# Altium schematic sheet Voltage_sensor_UVW.SchDoc
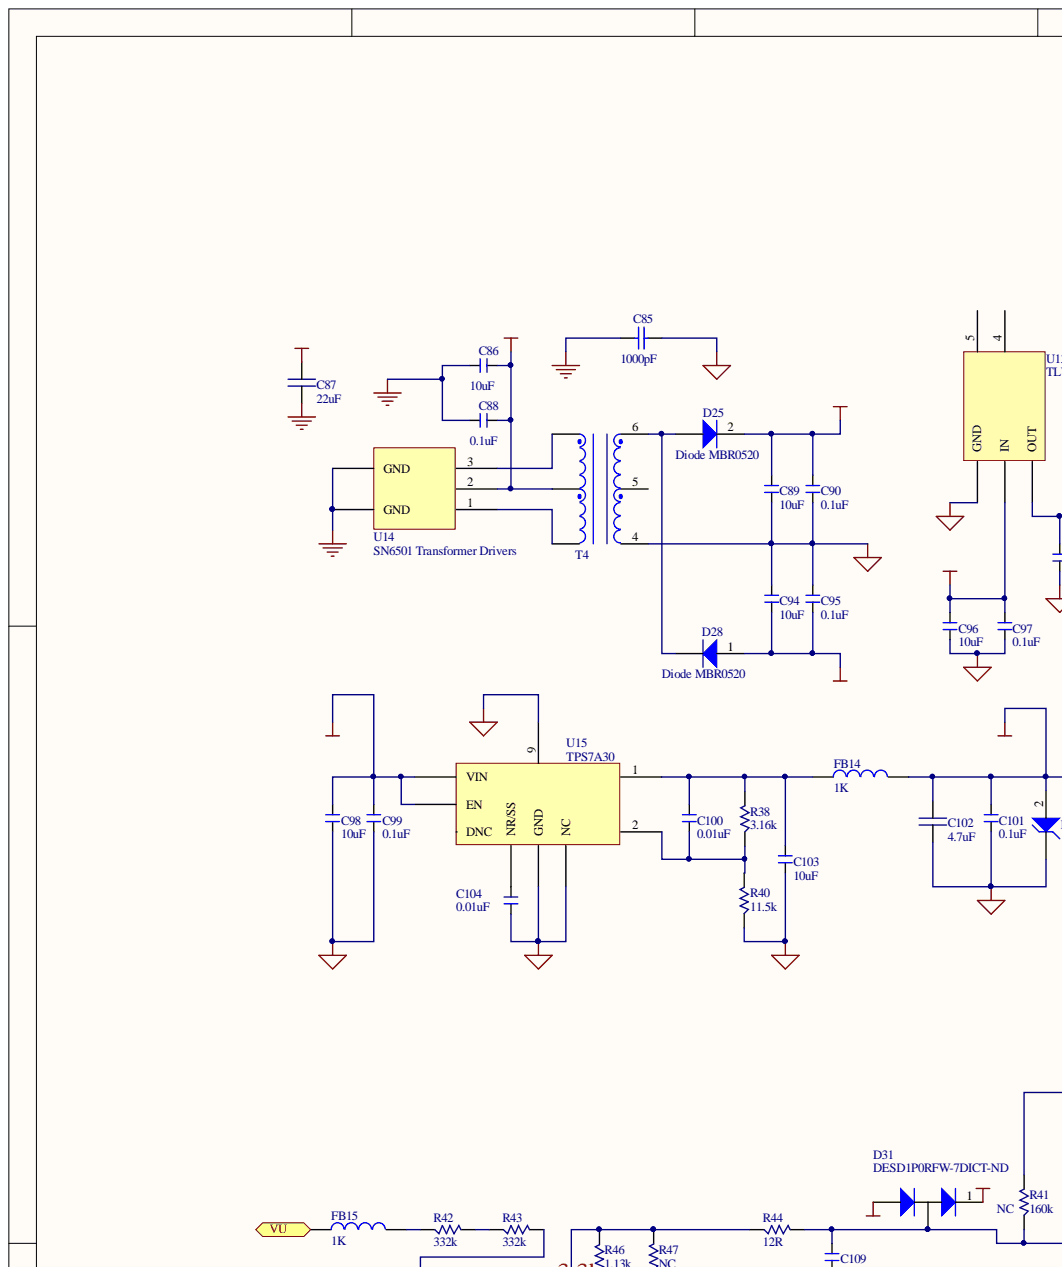
[diagram p0: Voltage_sensor_UVW.SchDoc, top left]
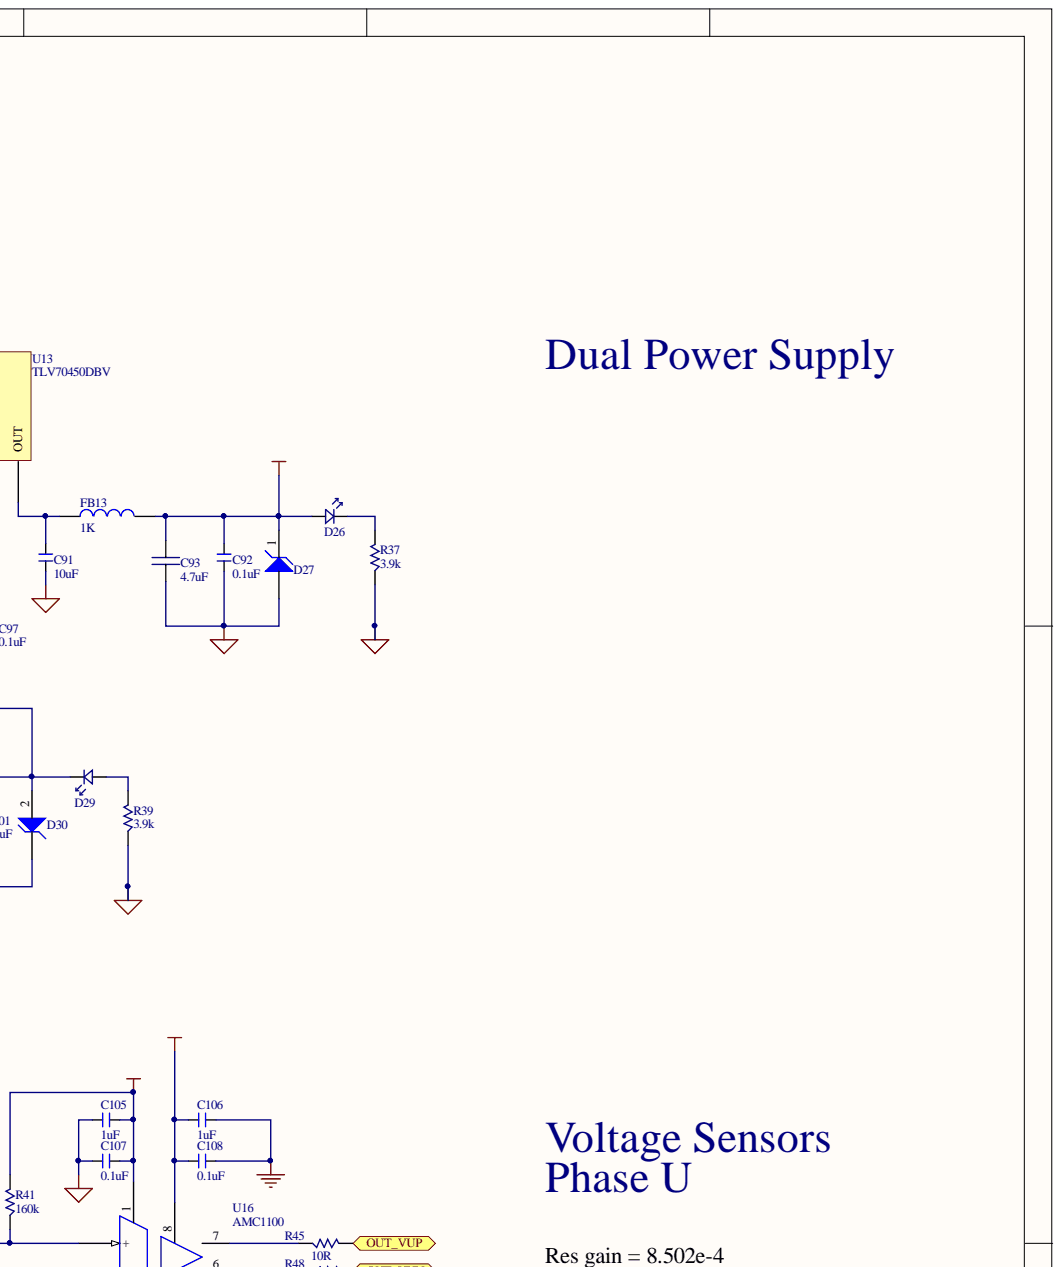
[diagram p1: Voltage_sensor_UVW.SchDoc, top right]
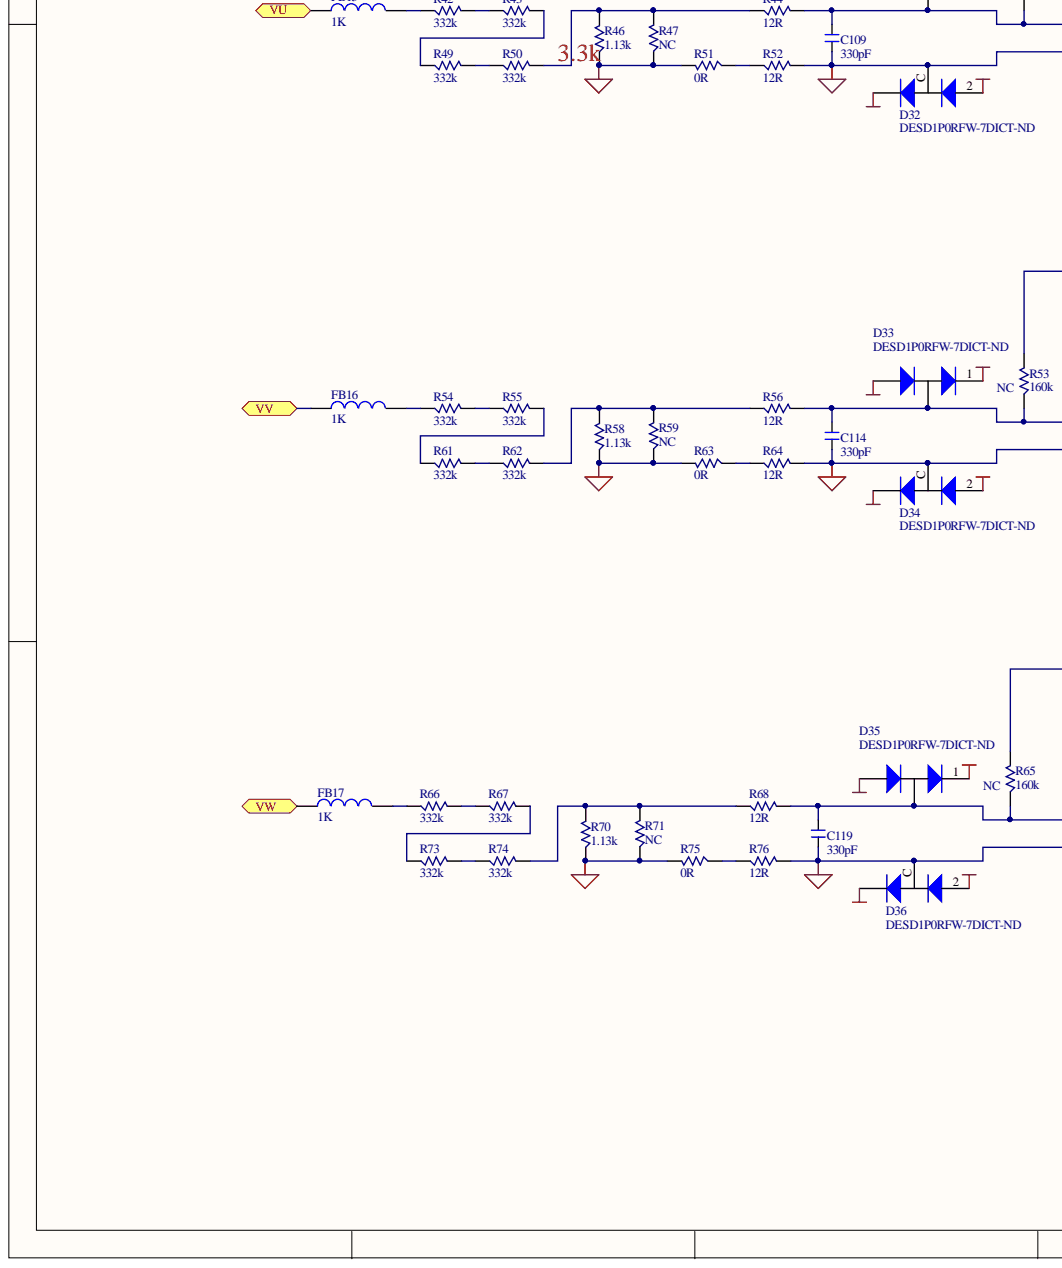
[diagram p2: Voltage_sensor_UVW.SchDoc, bottom left]
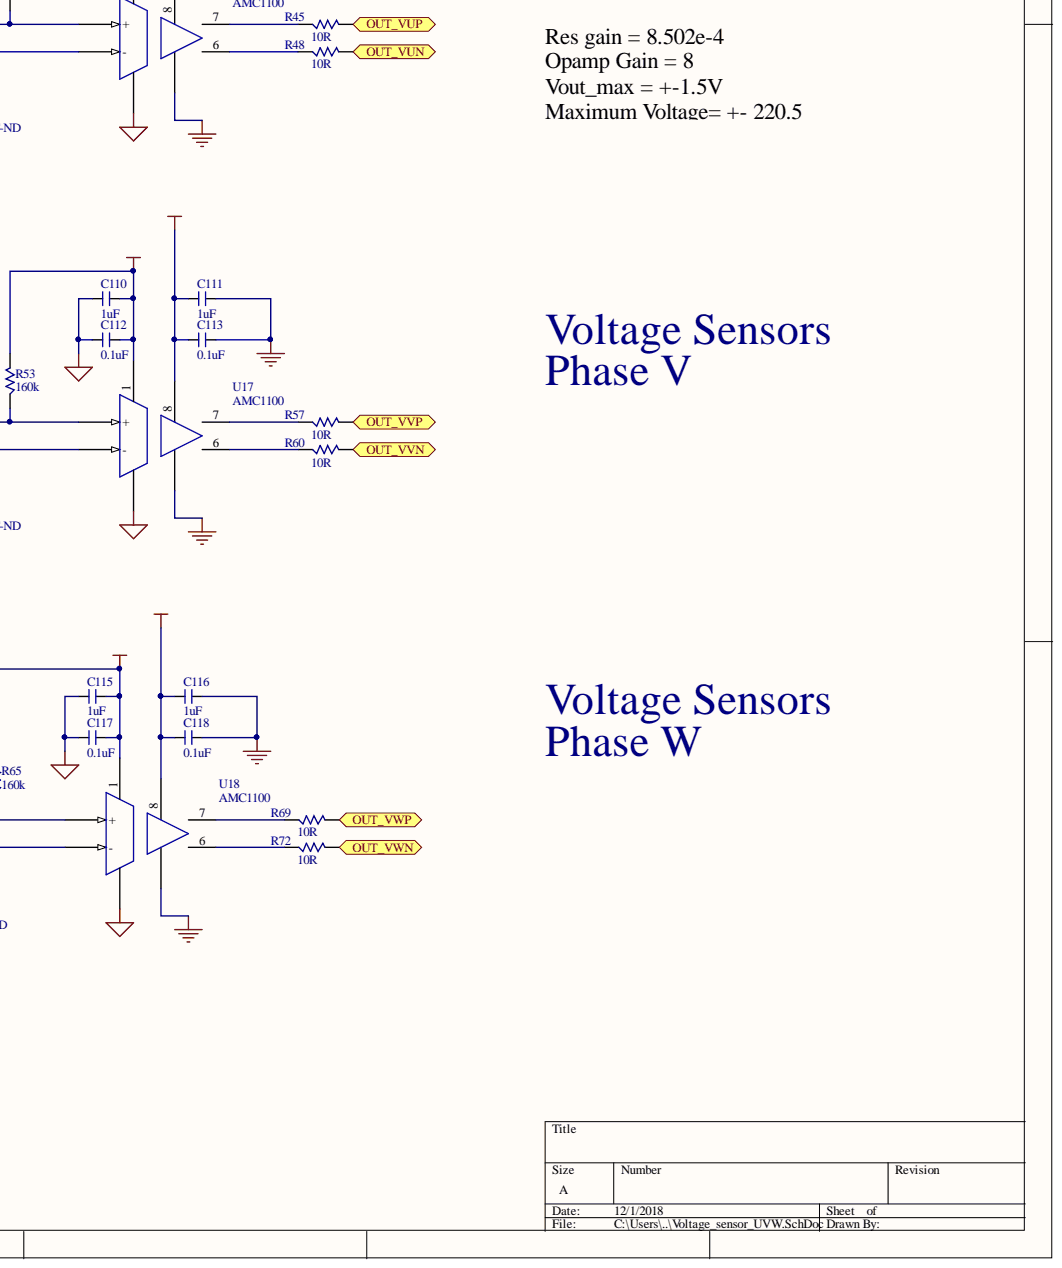
[diagram p3: Voltage_sensor_UVW.SchDoc, bottom right]

## Parts
C85  value 1000pF  [RAD-0.3]  (hidden comment: Cap TH)
C86  value 10uF  [0603 (1608 Metric)]  (hidden comment: Cap 0603_new)
C87  value 22uF  [0805 (2012 metric)]  (hidden comment: Cap 0805)
C88  value 0.1uF  [0603 (1608 Metric)]  (hidden comment: Cap 0603_new)
C89  value 10uF  [0603 (1608 Metric)]  (hidden comment: Cap 0603_new)
C90  value 0.1uF  [0603 (1608 Metric)]  (hidden comment: Cap 0603_new)
C91  value 10uF  [0603 (1608 Metric)]  (hidden comment: Cap 0603_new)
C92  value 0.1uF  [0603 (1608 Metric)]  (hidden comment: Cap 0603_new)
C93  value 4.7uF  [0805 (2012 metric)]  (hidden comment: Cap 0805)
C94  value 10uF  [0603 (1608 Metric)]  (hidden comment: Cap 0603_new)
C95  value 0.1uF  [0603 (1608 Metric)]  (hidden comment: Cap 0603_new)
C96  value 10uF  [0603 (1608 Metric)]  (hidden comment: Cap 0603_new)
C97  value 0.1uF  [0603 (1608 Metric)]  (hidden comment: Cap 0603_new)
C98  value 10uF  [0603 (1608 Metric)]  (hidden comment: Cap 0603_new)
C99  value 0.1uF  [0603 (1608 Metric)]  (hidden comment: Cap 0603_new)
C100  value 0.01uF  [0603 (1608 Metric)]  (hidden comment: Cap 0603_new)
C101  value 0.1uF  [0603 (1608 Metric)]  (hidden comment: Cap 0603_new)
C102  value 4.7uF  [0805 (2012 metric)]  (hidden comment: Cap 0805)
C103  value 10uF  [0603 (1608 Metric)]  (hidden comment: Cap 0603_new)
C104  value 0.01uF  [0603 (1608 Metric)]  (hidden comment: Cap 0603_new)
C105  value 1uF  [0603 (1608 Metric)]  (hidden comment: Cap 0603_new)
C106  value 1uF  [0603 (1608 Metric)]  (hidden comment: Cap 0603_new)
C107  value 0.1uF  [0603 (1608 Metric)]  (hidden comment: Cap 0603_new)
C108  value 0.1uF  [0603 (1608 Metric)]  (hidden comment: Cap 0603_new)
C109  value 330pF  [0603 (1608 Metric)]  (hidden comment: Cap 0603_new)
C110  value 1uF  [0603 (1608 Metric)]  (hidden comment: Cap 0603_new)
C111  value 1uF  [0603 (1608 Metric)]  (hidden comment: Cap 0603_new)
C112  value 0.1uF  [0603 (1608 Metric)]  (hidden comment: Cap 0603_new)
C113  value 0.1uF  [0603 (1608 Metric)]  (hidden comment: Cap 0603_new)
C114  value 330pF  [0603 (1608 Metric)]  (hidden comment: Cap 0603_new)
C115  value 1uF  [0603 (1608 Metric)]  (hidden comment: Cap 0603_new)
C116  value 1uF  [0603 (1608 Metric)]  (hidden comment: Cap 0603_new)
C117  value 0.1uF  [0603 (1608 Metric)]  (hidden comment: Cap 0603_new)
C118  value 0.1uF  [0603 (1608 Metric)]  (hidden comment: Cap 0603_new)
C119  value 330pF  [0603 (1608 Metric)]  (hidden comment: Cap 0603_new)
D25  Diode MBR0520  [SOD-123]
D26  LED  [0805 (2012 Metric) Diode]  (value not drawn)
D27  (no value)  [POWERDI]
D28  Diode MBR0520  [SOD-123]
D29  LED  [0805 (2012 Metric) Diode]  (value not drawn)
D30  (no value)  [POWERDI]
D31  Part: DESD1P0RFW-7DICT-ND  [SOT323_ESD]  (hidden comment: TVS - Diodes)
D32  Part: DESD1P0RFW-7DICT-ND  [SOT323_ESD]  (hidden comment: TVS - Diodes)
D33  Part: DESD1P0RFW-7DICT-ND  [SOT323_ESD]  (hidden comment: TVS - Diodes)
D34  Part: DESD1P0RFW-7DICT-ND  [SOT323_ESD]  (hidden comment: TVS - Diodes)
D35  Part: DESD1P0RFW-7DICT-ND  [SOT323_ESD]  (hidden comment: TVS - Diodes)
D36  Part: DESD1P0RFW-7DICT-ND  [SOT323_ESD]  (hidden comment: TVS - Diodes)
FB13  value 1K  [0603 (1608 Metric)]  (hidden comment: Ferrite bead)
FB14  value 1K  [0603 (1608 Metric)]  (hidden comment: Ferrite bead)
FB15  value 1K  [0603 (1608 Metric)]  (hidden comment: Ferrite bead)
FB16  value 1K  [0603 (1608 Metric)]  (hidden comment: Ferrite bead)
FB17  value 1K  [0603 (1608 Metric)]  (hidden comment: Ferrite bead)
R37  value 3.9k  [0603 (1608 Metric)]  (hidden comment: Resistor 0603)
R38  value 3.16k  [0603 (1608 Metric)]  (hidden comment: Resistor 0603)
R39  value 3.9k  [0603 (1608 Metric)]  (hidden comment: Resistor 0603)
R40  value 11.5k  [0603 (1608 Metric)]  (hidden comment: Resistor 0603)
R41  NC  value 160k  [0603 (1608 Metric)]
R42  value 332k  [1206]  (hidden comment: Resistor 1206)
R43  value 332k  [1206]  (hidden comment: Resistor 1206)
R44  value 12R  [1206]  (hidden comment: Resistor 1206)
R45  value 10R  [0603 (1608 Metric)]  (hidden comment: Resistor 0603)
R46  value 1.13k  [1206]  (hidden comment: Resistor 1206)
R47  value NC  [1206]  (hidden comment: Resistor 1206)
R48  value 10R  [0603 (1608 Metric)]  (hidden comment: Resistor 0603)
R49  value 332k  [1206]  (hidden comment: Resistor 1206)
R50  value 332k  [1206]  (hidden comment: Resistor 1206)
R51  value 0R  [0603 (1608 Metric)]  (hidden comment: Resistor 0603)
R52  value 12R  [1206]  (hidden comment: Resistor 1206)
R53  NC  value 160k  [0603 (1608 Metric)]
R54  value 332k  [1206]  (hidden comment: Resistor 1206)
R55  value 332k  [1206]  (hidden comment: Resistor 1206)
R56  value 12R  [1206]  (hidden comment: Resistor 1206)
R57  value 10R  [0603 (1608 Metric)]  (hidden comment: Resistor 0603)
R58  value 1.13k  [1206]  (hidden comment: Resistor 1206)
R59  value NC  [1206]  (hidden comment: Resistor 1206)
R60  value 10R  [0603 (1608 Metric)]  (hidden comment: Resistor 0603)
R61  value 332k  [1206]  (hidden comment: Resistor 1206)
R62  value 332k  [1206]  (hidden comment: Resistor 1206)
R63  value 0R  [0603 (1608 Metric)]  (hidden comment: Resistor 0603)
R64  value 12R  [1206]  (hidden comment: Resistor 1206)
R65  NC  value 160k  [0603 (1608 Metric)]
R66  value 332k  [1206]  (hidden comment: Resistor 1206)
R67  value 332k  [1206]  (hidden comment: Resistor 1206)
R68  value 12R  [1206]  (hidden comment: Resistor 1206)
R69  value 10R  [0603 (1608 Metric)]  (hidden comment: Resistor 0603)
R70  value 1.13k  [1206]  (hidden comment: Resistor 1206)
R71  value NC  [1206]  (hidden comment: Resistor 1206)
R72  value 10R  [0603 (1608 Metric)]  (hidden comment: Resistor 0603)
R73  value 332k  [1206]  (hidden comment: Resistor 1206)
R74  value 332k  [1206]  (hidden comment: Resistor 1206)
R75  value 0R  [0603 (1608 Metric)]  (hidden comment: Resistor 0603)
R76  value 12R  [1206]  (hidden comment: Resistor 1206)
T4  750313769  [Xmr SN6501]  (value not drawn)
U13  TLV70450DBV  [SOT23-5]
U14  SN6501 Transformer Drivers  [SOT23-5]
U15  TPS7A30  [8-TSSOP]
U16  AMC1100  [SOIC8]
U17  AMC1100  [SOIC8]
U18  AMC1100  [SOIC8]

## Ports
OUT_VUN, OUT_VUP, OUT_VVN, OUT_VVP, OUT_VWN, OUT_VWP, VU, VV, VW

## Nets
+5V_LV: C86.2 C87.2 C88.2 C106.1 C108.1 C111.1 C113.1 C116.1 C118.1 T4.2 U14.2 U16.8 U17.8 U18.8
+5V_PH: C92.2 C93.2 C105.2 C107.2 C110.2 C112.2 C115.2 C117.2 D26.A D27.1 D31.1 D32.1 D33.1 D34.1 D35.1 D36.1 FB13.2 R41.2 R53.2 R65.2 U16.1 U17.1 U18.1
+6V_PH: C89.2 C90.2 C96.2 C97.2 D25.2 U13.2(IN)
-5V_PH: C101.2 C102.2 D29.K D30.2 D31.2 D32.2 D33.2 D34.2 D35.2 D36.2 FB14.2
-6V_PH: C94.1 C95.1 C98.2 C99.2 D28.1 U15.5(EN) U15.8(VIN)
GND_LV: C85.1 C86.1 C87.1 C88.1 C106.2 C108.2 C111.2 C113.2 C116.2 C118.2 U14.4(GND) U14.5(GND) U16.5 U17.5 U18.5
GND_PH: C85.2 C89.1 C90.1 C91.1 C92.1 C93.1 C94.2 C95.2 C96.1 C97.1 C98.1 C99.1 C101.1 C102.1 C103.1 C104.1 C105.1 C107.1 C109.2 C110.1 C112.1 C114.2 C115.1 C117.1 C119.2 D27.2 D30.1 D32.C D34.C D36.C R37.1 R39.1 R40.1 R52.2 R64.2 R76.2 T4.4 U13.1(GND) U15.3(NC) U15.4(GND) U15.9 U16.3(-) U16.4 U17.3(-) U17.4 U18.3(-) U18.4
N_HV: R46.1 R47.1 R51.2 R58.1 R59.1 R63.2 R70.1 R71.1 R75.2
OUT_VUN: R48.2 ; port OUT_VUN
OUT_VUP: R45.2 ; port OUT_VUP
OUT_VVN: R60.2 ; port OUT_VVN
OUT_VVP: R57.2 ; port OUT_VVP
OUT_VWN: R72.2 ; port OUT_VWN
OUT_VWP: R69.2 ; port OUT_VWP
VU: FB15.1 ; port VU
VV: FB16.1 ; port VV
VW: FB17.1 ; port VW
NetC91_2: C91.2 FB13.1 U13.3(OUT)
NetC100_1: C100.1 C103.2 FB14.1 R38.2 U15.1
NetC100_2: C100.2 R38.1 R40.2 U15.2
NetC104_2: C104.2 U15.6(NR/SS)
NetC109_1: C109.1 D31.C R41.1 R44.2 U16.2(+)
NetC114_1: C114.1 D33.C R53.1 R56.2 U17.2(+)
NetC119_1: C119.1 D35.C R65.1 R68.2 U18.2(+)
NetD25_1: D25.1 D28.2 T4.6
NetD26_K: D26.K R37.2
NetD29_A: D29.A R39.2
NetFB15_2: FB15.2 R42.1
NetFB16_2: FB16.2 R54.1
NetFB17_2: FB17.2 R66.1
NetR42_2: R42.2 R43.1
NetR43_2: R43.2 R49.1
NetR44_1: R44.1 R46.2 R47.2 R50.2
NetR45_1: R45.1 U16.7
NetR48_1: R48.1 U16.6
NetR49_2: R49.2 R50.1
NetR51_1: R51.1 R52.1
NetR54_2: R54.2 R55.1
NetR55_2: R55.2 R61.1
NetR56_1: R56.1 R58.2 R59.2 R62.2
NetR57_1: R57.1 U17.7
NetR60_1: R60.1 U17.6
NetR61_2: R61.2 R62.1
NetR63_1: R63.1 R64.1
NetR66_2: R66.2 R67.1
NetR67_2: R67.2 R73.1
NetR68_1: R68.1 R70.2 R71.2 R74.2
NetR69_1: R69.1 U18.7
NetR72_1: R72.1 U18.6
NetR73_2: R73.2 R74.1
NetR75_1: R75.1 R76.1
NetT4_1: T4.1 U14.3
NetT4_3: T4.3 U14.1

Not connected: T4 5; U13 4 5; U15 7

## Library data of the parts
C85 = Cap: CAP CER 1000PF 1KV Y5P RADIAL; Part: 1251PH-ND; Component Kind: Standard; LatestRevisionDate: 17-Jul-2002; LatestRevisionNote: Re-released for DXP Platform.; Library Name: Miscellaneous Devices.IntLib; PackageDescription: Radial Cap, Thru-Hole; 2 Leads; 0.3 in Pin Spacing; PackageReference: RAD-0.3; Published: 8-Jun-2000; Signal Integrity: Cap; Simulation: CAP; Publisher: Altium Limited
C86 C89 C91 C94 C96 C98 C103 = Cap 0603_new: CAP CER 10UF 35V X5R 0805; part: 490-10515-1-ND; Component Kind: Standard; Library Name: Library_Microgrid.SchLib
C87 = Cap 0805: CAP CER 22UF 35V X5R 0805; Part: 445-14428-1-ND; Component Kind: Standard; Library Name: Library_Microgrid.SchLib
C88 C90 C92 C95 C97 C99 C101 C107 C108 C112 C113 C117 C118 = Cap 0603_new: CAP CER 0.1UF 50V Y5V 0603; part: 311-1343-6-ND; Component Kind: Standard; Library Name: Library_Microgrid.SchLib
C93 C102 = Cap 0805: CAP CER 4.7UF 50V X5R 0805; Part: 445-5980-1-ND; Component Kind: Standard; Library Name: Library_Microgrid.SchLib
C100 C104 = Cap 0603_new: CAP CER 10000PF 100V X7R 0603; part: 478-7418-1-ND; Component Kind: Standard; Library Name: Library_Microgrid.SchLib
C105 C106 C110 C111 C115 C116 = Cap 0603_new: CAP CER 1UF 50V X5R 0603; part: 1276-1860-1-ND; Component Kind: Standard; Library Name: Library_Microgrid.SchLib
C109 C114 C119 = Cap 0603_new: CAP CER 330PF 50V C0G 0603; part: 445-7033-2-ND; Component Kind: Standard; Library Name: Library_Microgrid.SchLib
D25 D28 = Diode MBR0520: DIODE SCHOTTKY 20V 500MA SOD123; Part: MBR0520LT1GOSCT-ND; Component Kind: Standard; Library Name: Library_Microgrid.SchLib
D26 D29 = LED: LED 0805; Component Kind: Standard; Library Name: Library_Microgrid.SchLib
D27 D30 = Diode Zener POWERDI123: Part: MMSZ5228B-FDICT-ND; Component Kind: Standard; Description: DIODE ZENER POWERDI123; Library Name: Library_Microgrid.SchLib
D31-D36 = Diode_ESD: TVS DIODE 70VWM 8VC SOT323; Value: ESD; Component Kind: Standard; Library Name: Library_Microgrid.SchLib
FB13-FB17 = Ferrite bead: FERRITE BEAD 1 KOHM 0603 1LN; Part: 445-8672-1-ND; Component Kind: Standard; Library Name: Library_Microgrid.SchLib
R37 R39 = Resistor 0603: RES SMD 3.9K OHM 5% 1/10W 0603; Part: 541-3.9KGCT-ND; Component Kind: Standard; Library Name: Library_Microgrid.SchLib
R38 = Resistor 0603: RES SMD 3.16K OHM 1% 1/10W 0603; Part: 311-3.16KHRCT-ND; Component Kind: Standard; Library Name: Library_Microgrid.SchLib
R40 = Resistor 0603: RES SMD 11.5K OHM 1% 1/10W 0603; Part: 541-11.5KHCT-ND; Component Kind: Standard; Library Name: Library_Microgrid.SchLib
R41 R53 R65 = Resistor 0603: Component Kind: Standard; Description: Resistor 0603; Library Name: Library_Microgrid.SchLib
R42 R43 R49 R50 R54 R55 R61 R62 R66 R67 R73 R74 = Resistor 1206: RES SMD 332K OHM 0.1% 1/4W 1206; Part: RG32P332KBCT-ND; Component Kind: Standard; Library Name: Library_Microgrid.SchLib
R44 R52 R56 R64 R68 R76 = Resistor 1206: 12 Ohm ±0.5% 0.25W, 1/4W Chip Resistor 1206; Part: 311-2961-1-ND; Component Kind: Standard; Library Name: Library_Microgrid.SchLib
R45 R48 R57 R60 R69 R72 = Resistor 0603: RES SMD 10 OHM 0.05% 1/10W 0603; Part: RNCF0603AKT10R0CT-ND; Component Kind: Standard; Library Name: Library_Microgrid.SchLib
R46 R58 R70 = Resistor 1206: RES SMD 1.13K OHM 0.1% 1/4W 1206; Part: P1.13KBCCT-ND; Component Kind: Standard; Library Name: Library_Microgrid.SchLib
T4 = 750313769: TRANSFORMER SMD TR1.7 SN6501; Part: 1297-1107-1-ND; Component Kind: Standard; Library Name: Library_Microgrid.SchLib
U13 = TLV70450DBV: IC REG LDO 5V 0.15A SOT23-5; Part: 296-39287-1-ND; Component Kind: Standard; Library Name: Library_Microgrid.SchLib
U14 = SN6501: IC REG CONV PWR SUPPLIES SOT23-5; Part: 296-37700-1-ND; Component Kind: Standard; Library Name: Library_Microgrid.SchLib
U15 = TPS7A30: IC REG LDO NEG ADJ 0.2A 8MSOP; Part: 296-27750-1-ND; Component Kind: Standard; Library Name: Library_Microgrid.SchLib
U16-U18 = AMC1100: IC OPAMP ISOLATION 100KHZ 8SOIC; Part: 296-38822-5-ND; Component Kind: Standard; Library Name: Library_Microgrid.SchLib
R47 R59 R71 = Resistor 1206: Component Kind: Standard; Library Name: Library_Microgrid.SchLib
R51 R63 R75 = Resistor 0603: Component Kind: Standard; Library Name: Library_Microgrid.SchLib
